# Altium schematic sheet Sheet1.SchDoc
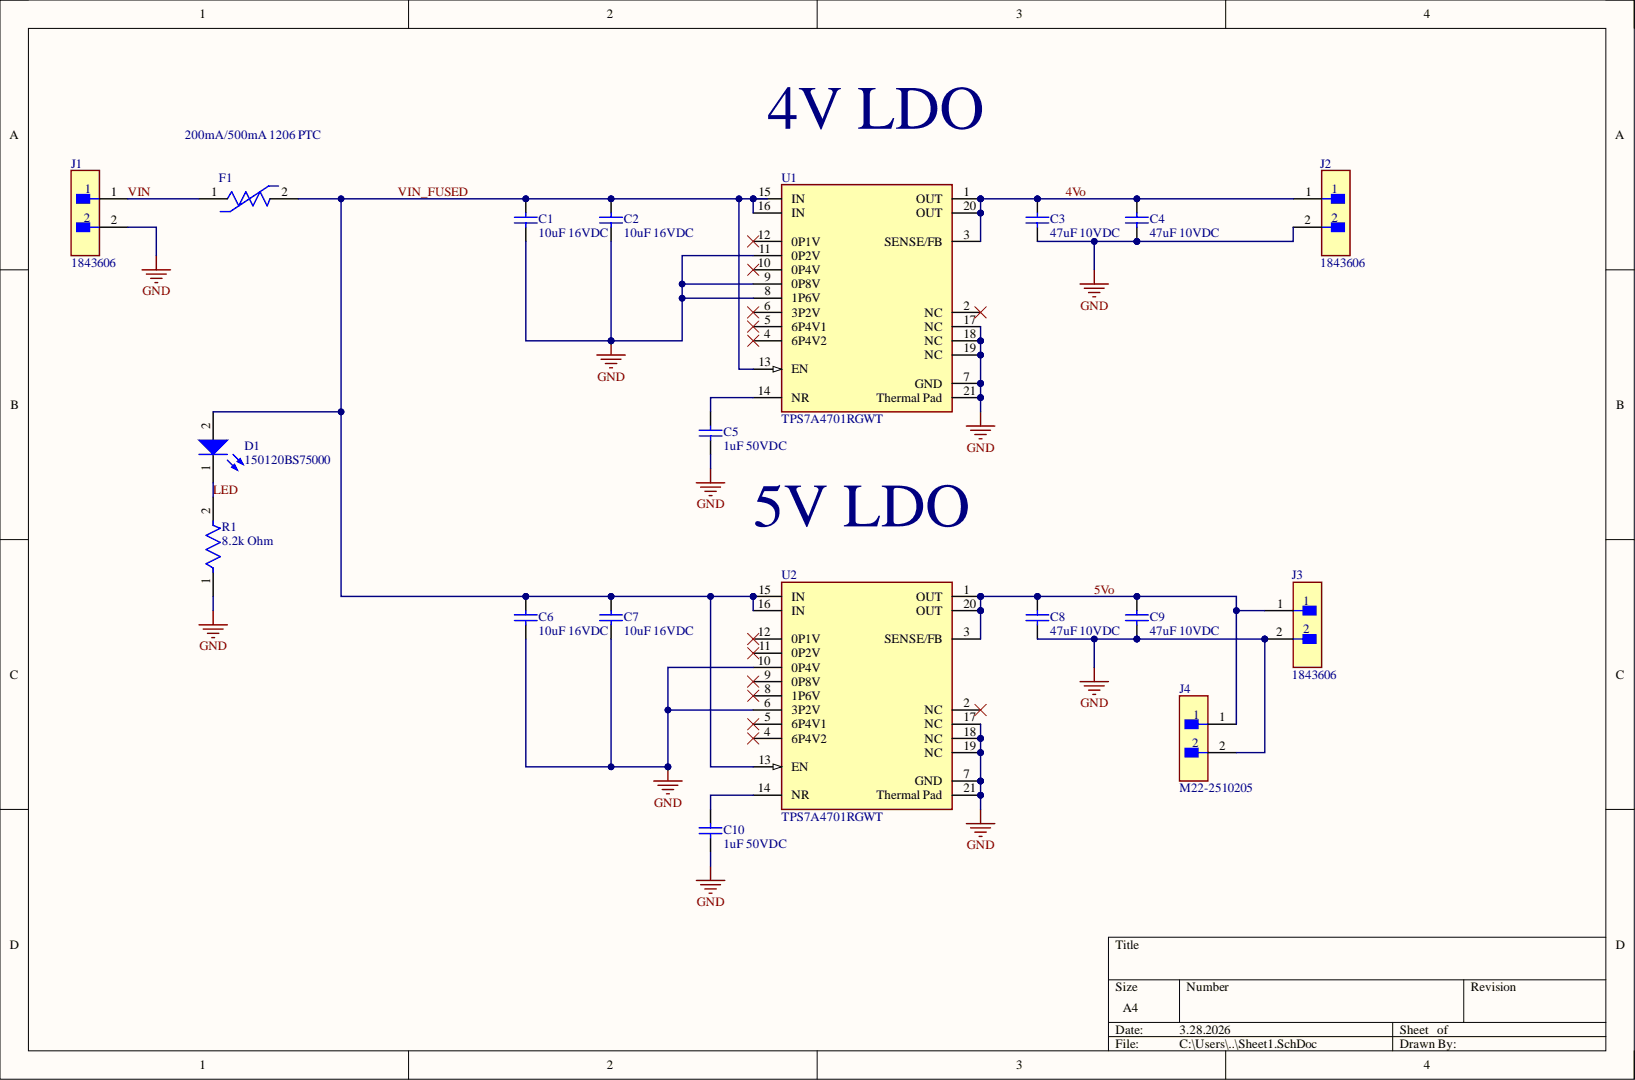
[diagram p0: Sheet1.SchDoc]

## Parts
C1  10uF 16VDC  [CAPC1206(3216)170_M]
C2  10uF 16VDC  [CAPC1206(3216)170_M]
C3  47uF 10VDC  [CAPC1206(3216)170_M]
C4  47uF 10VDC  [CAPC1206(3216)170_M]
C5  1uF 50VDC  [CAPC1206(3216)170_M]
C6  10uF 16VDC  [CAPC1206(3216)170_M]
C7  10uF 16VDC  [CAPC1206(3216)170_M]
C8  47uF 10VDC  [CAPC1206(3216)170_M]
C9  47uF 10VDC  [CAPC1206(3216)170_M]
C10  1uF 50VDC  [CAPC1206(3216)170_M]
D1  150120BS75000  [WL-SMCW_1206_150120xx75000]
F1  200mA/500mA 1206 PTC  [FP-nanoSMD400LR-IPC_A]
J1  1843606  [FP-1843606-MFG]
J2  1843606  [FP-1843606-MFG]
J3  1843606  [FP-1843606-MFG]
J4  M22-2510205  [FP-M22-2510205-MFG]
R1  8.2k Ohm  [FP-RMCF1206-IPC_A]
U1  TPS7A4701RGWT  [FP-RGW0020A-MFG]
U2  TPS7A4701RGWT  [FP-RGW0020A-MFG]

## Nets
GND: C1.1 C2.1 C3.1 C4.1 C5.1 C6.1 C7.1 C8.1 C9.1 C10.1 J1.2 J2.2 J3.2 J4.2 R1.1 U1.7(GND) U1.8(1P6V) U1.9(0P8V) U1.11(0P2V) U1.17(NC) U1.18(NC) U1.19(NC) U1.21(Thermal_Pad) U2.6(3P2V) U2.7(GND) U2.10(0P4V) U2.17(NC) U2.18(NC) U2.19(NC) U2.21(Thermal_Pad)
4Vo: C3.2 C4.2 J2.1 U1.1(OUT) U1.3(SENSE/FB) U1.20(OUT)
5Vo: C8.2 C9.2 J3.1 J4.1 U2.1(OUT) U2.3(SENSE/FB) U2.20(OUT)
LED: D1.1 R1.2
VIN: F1.1 J1.1
VIN_FUSED: C1.2 C2.2 C6.2 C7.2 D1.2 F1.2 U1.13(EN) U1.15(IN) U1.16(IN) U2.13(EN) U2.15(IN) U2.16(IN)
NetC5_2: C5.2 U1.14(NR)
NetC10_2: C10.2 U2.14(NR)

Not connected: U1 2(NC) 4(6P4V2) 5(6P4V1) 6(3P2V) 10(0P4V) 12(0P1V); U2 2(NC) 4(6P4V2) 5(6P4V1) 8(1P6V) 9(0P8V) 11(0P2V) 12(0P1V)

## Library data of the parts
C1 C2 C6 C7 = CMP-1037-03125-1: CL31B106KOHNNNE10uF 16VDC; Case-EIA: 1206; Case-Metric: 3216; Rated Voltage: 100 V; Tolerance: Â±2%; Value: 4.7nF; Component Kind: Standard; Library Name: Altium Content Vault; Max Thickness: 1.7 mm; Technology: SMT
C3 C4 C8 C9 = CMP-1037-03125-1: CL31A476MPHNNN 47uF 10V; Case-EIA: 1206; Case-Metric: 3216; Rated Voltage: 100 V; Tolerance: Â±2%; Value: 4.7nF; Component Kind: Standard; Library Name: Altium Content Vault; Max Thickness: 1.7 mm; Technology: SMT
C5 C10 = CMP-1037-03125-1: CC1206KKX7R7BB1051uF 50VDC; Case-EIA: 1206; Case-Metric: 3216; Rated Voltage: 100 V; Tolerance: Â±2%; Value: 4.7nF; Component Kind: Standard; Library Name: Altium Content Vault; Max Thickness: 1.7 mm; Technology: SMT
D1 = CMP-1426-00015-3: WL-SMCW SMT Mono-Color Chip LED Waterclear; Manufacturer Package Identifier: LED_1206; Color: Blue; Dominant Wavelength: 470nm; Forward Current: 100mA; Forward Voltage: 3.2V; Lens Style: Clear, Rectangular; Luminous Intensity: 145mcd; Max Reverse Voltage (DC): 5V; Peak Wavelength: 465nm; Test Current: 20mA; Viewing Angle: 140°; Case Code (Metric): 3216; Case Code (Imperial): 1206; Case/Package: 1206; Component Kind: Standard; Height: 680µm; Length: 3.2mm; Library Name: Altium Content Vault; Max Operating Temperature: 85°C; Min Operating Temperature: -40°C; Mount: Surface Mount; Packaging: Tape and Reel; REACH SVHC: Unknown; RoHS: Compliant; Wavelength: 470nm; Width: 1.6mm
F1 = CMP-08606-000072-1: PTC RESET FUSE 6V 4A 1206; Component Kind: Standard; Library Name: Altium Content Vault; RoHS Compliant: Yes
J1-J3 = CMP-00298-00064326-1: 1843606 Phoenix Contact 1843606 MCV 1, 5/ 2-G-3, 5 2 Way Terminal Block Header 8A 3.5mm; NexarPartId: 64326; Approvals: CSA, UL; Connector Type: Plug; Gender: Male; Number of Contacts: 2; Number of Levels: 1; Voltage: 300V; Voltage Rating: 160V; Voltage Rating (DC): 640mV; Ambient Temperature Range High: 100°C; Color: Green; Component Kind: Standard; Contact Material: Tin; Contact Plating: Tin; Current: 8A; Current Rating: 8A; Depth: 7.25mm; Flammability Rating: UL94 V-0; Height: 8.4mm; Housing Color: Green; Housing Material: Polybutylene; Lead Free: Lead Free; Lead Pitch: 3.81mm; Length: 8.4mm; Library Name: Altium Content Vault; Material: PBT; Max Current Rating: 8A; Max Operating Temperature: 100°C; Min Operating Temperature: -40°C; Mount: Through Hole; Number of Poles: 2; Number of Positions: 2; Number of Positions Per Level: 2; Number of Rows: 1; Orientation: Straight; Pitch: 3.5mm; REACH SVHC: No SVHC; RoHS: Compliant; Termination: Solder; Voltage Rating (AC): 160V; Weight: 113.398093g; Width: 7.25mm
J4 = CMP-15831-000005-1: CONN HEADER VERT 2POS 2MM; Manufacturer: Harwin; Conn Gender: Male; Conn Orientation: Vertical; Current Rating: 2A; Number Of Contacts: 2; Automotive: No; Category: Conn; Component Kind: Standard; ComponentLink2Description: Datasheet; ComponentLink2URL: https://cdn.harwin.com/pdfs/C018XX_M22_Series_Connectors.pdf; Contact Material: Gold,Nickel; Device Class L1: Connectors; Device Class L2: Headers and Wire Housings; Device Class L3: unset; Digikey Description: CONN HEADER VERT 2POS 2MM; Footprint Url: https://cdn.harwin.com/pdfs/M22-251.pdf; Height: 5.45mm; Lead Free: Yes; Library Name: Altium Content Vault; Manufacturer Part Number: M22-2510205; Mouser Description: Headers & Wire Housings 2 PIN SIL VERTICAL GOLD PIN HEADER; Number Of Rows: 1; Octopart: https://octopart.com/m22-2510205-harwin-2538221; Package: 0; Pitch: 2mm; RoHS: TRUE; Temperature: -40°C to +105°C; Temperature Range High: +105°C; Temperature Range Low: -40°C
R1 = CMP-26527-000539-1: 8.2k Ohm 1206; Max Power Dissipation: 250mW; Resistance: 63.4kR; Temperature Coefficient: 100ppm/°C; Tolerance: 1%; Case/Package: 1206; Component Kind: Standard; Contact Plating: Tin; Features: Automotive AEC-Q200; Height: 0.028inch; Length: 0.126inch; Library Name: Altium Content Vault; Max Operating Temperature: 155°C; Min Operating Temperature: -55°C; Mount: Surface Mount; Number of Terminations: 2; Package Quantity: 10000; Packaging: Tape and Reel; Radiation Hardening: No; Resistor Type: Thick Film; RoHS Compliant: Yes; Series: RMCF; Width: 0.063inch
U1 U2 = CMP-04917-000017-1: IC REG LINEAR POS ADJ 1A 20VQFN; Manufacturer: Texas Instruments; Accuracy Percentage: 2.5%; Dropout Voltage: 307mV; Max Junction Temp: +125°C; Max Supply Voltage: 35V; Min Supply Voltage: 3V; Nominal Supply Current: 0.58-6.1mA; Number Of Outputs: 1; Output Type: AdjustableProgrammable; Output Voltage: 1.4-34mV; Standoff Height: 0mm; Automotive: No; Category: IC; Component Kind: Standard; ComponentLink2Description: Datasheet; ComponentLink2URL: http://www.ti.com/lit/ds/symlink/tps7a47.pdf; Device Class L1: Integrated Circuits (ICs); Device Class L2: Power Management ICs; Device Class L3: Voltage Regulators - Linear; Digikey Description: IC REG LINEAR POS ADJ 1A 20VQFN; Height: 1mm; Lead Free: Yes; Library Name: Altium Content Vault; Manufacturer Part Number: TPS7A4701RGWT; Mouser Description: LDO Voltage Regulators 36V 1A 4.17uVRMS RF LDO VTG Reg; Octopart: https://octopart.com/tps7a4701rgwt-texas+instruments-30261754; Output Current: 1A; Package: RGW0020A; RoHS: TRUE; Temperature: -40°C to +125°C; Temperature Range High: +125°C; Temperature Range Low: -40°C
